# Altium schematic sheet RS485_M_V1.SchDoc
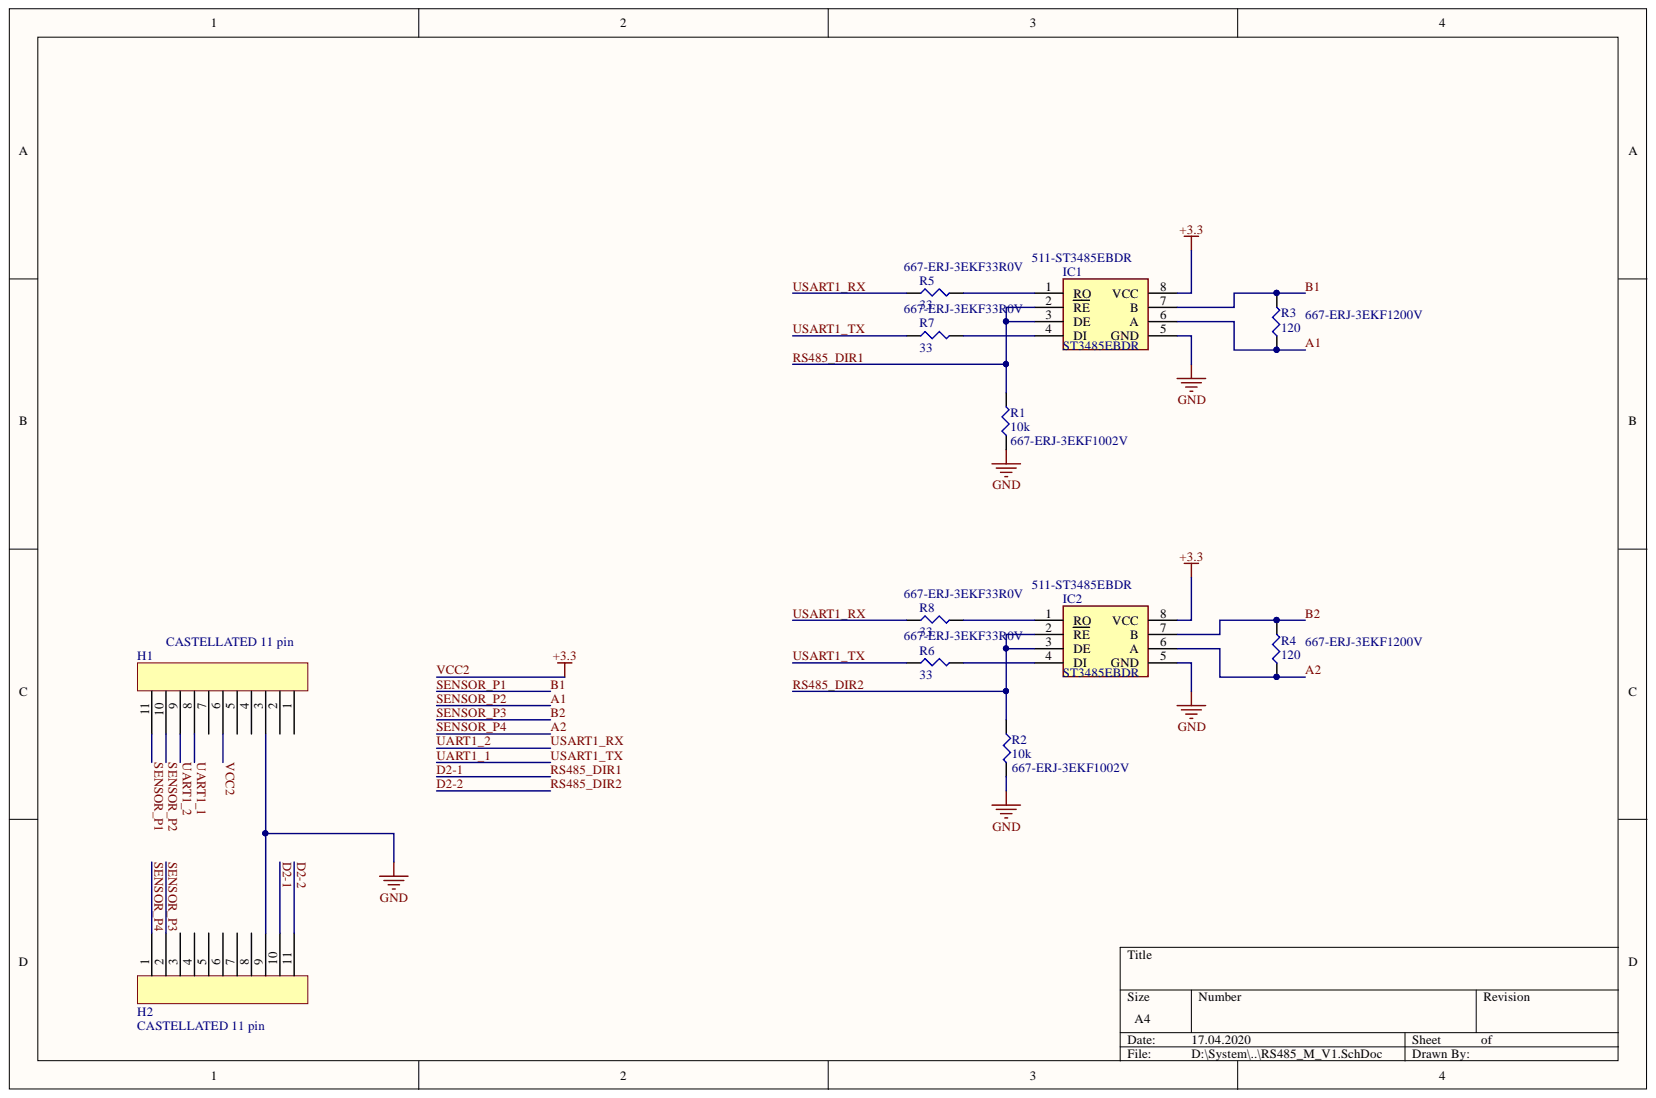
[diagram p0: RS485_M_V1.SchDoc]

## Parts
H1  CASTELLATED 11 pin  [CASTELLATED 11 pin]
H2  CASTELLATED 11 pin  [CASTELLATED 11 pin]
IC1  ST3485EBDR  Mouser2 Part Number: 511-ST3485EBDR  [SOIC127P600X175-8N]
IC2  ST3485EBDR  Mouser2 Part Number: 511-ST3485EBDR  [SOIC127P600X175-8N]
R1  10k  Supplier Part Number 1: 667-ERJ-3EKF1002V  [SMD-0603-RES]
R2  10k  Supplier Part Number 1: 667-ERJ-3EKF1002V  [SMD-0603-RES]
R3  120  Supplier Part Number 1: 667-ERJ-3EKF1200V  [SMD-0603-RES]
R4  120  Supplier Part Number 1: 667-ERJ-3EKF1200V  [SMD-0603-RES]
R5  33  Supplier Part Number 1: 667-ERJ-3EKF33R0V  [SMD-0603-RES]
R6  33  Supplier Part Number 1: 667-ERJ-3EKF33R0V  [SMD-0603-RES]
R7  33  Supplier Part Number 1: 667-ERJ-3EKF33R0V  [SMD-0603-RES]
R8  33  Supplier Part Number 1: 667-ERJ-3EKF33R0V  [SMD-0603-RES]

## Nets
GND: H1.3 H2.9 IC1.5(GND) IC2.5(GND) R1.1 R2.2
A1: H1.10 IC1.6(A) R3.1
A2: H2.1 IC2.6(A) R4.1
B1: H1.11 IC1.7(B) R3.2
B2: H2.2 IC2.7(B) R4.2
D2-1: H2.10 IC1.2(RE) IC1.3(DE) R1.2
D2-2: H2.11 IC2.2(RE) IC2.3(DE) R2.1
UART1_1: H1.8 R6.1 R7.1
UART1_2: H1.9 R5.1 R8.1
VCC2: H1.6 IC1.8(VCC) IC2.8(VCC)
NetIC1_1: IC1.1(RO) R5.2
NetIC1_4: IC1.4(DI) R7.2
NetIC2_1: IC2.1(RO) R8.2
NetIC2_4: IC2.4(DI) R6.2

Not connected: H1 1 2 4 5 7; H2 3 4 5 6 7 8

## Library data of the parts
IC1 IC2 = ST3485EBDR: Integrated Circuit; Manufacturer_Name: STMicroelectronics; Component Kind: Standard; Datasheet Link: https://componentsearchengine.com/Datasheets/1/ST3485EBDR.pdf; Height: 1.75mm; Manufacturer_Part_Number: ST3485EBDR; Mouser2 Price/Stock: https://www.mouser.com/Search/Refine.aspx?Keyword=511-ST3485EBDR; Supplier 1: Mouser; Supplier Part Number 1: 511-ST3485EBDR
R1 R2 = ERJ-3EKF1002V: RES SMD 10K OHM 1% 1/10W 0603; Manufacturer: Panasonic Electronic Components; @ qty: 1500; Factory Stock: 0; Minimum Quantity: 1; Power (Watts): 0.1W, 1/10W; Temperature Coefficient: ±100ppm/°C; Unit Price (USD): 0.00379; Component Kind: Standard; Composition: Thick Film; Datasheets: http://industrial.panasonic.com/www-cgi/jvcr13pz.cgi?E+PZ+3+AOA0002+ERJ3EKF1002V+7+WW; Digi-Key Part Number: P10.0KHCT-ND; Failure Rate: -; Features: Automotive AEC-Q200; Height - Seated (Max): 0.022" (0.55mm); Image: //media.digikey.com/Photos/Panasonic%20Photos/MFG_ERJ-P6W%20Series.jpg; Library Name: Panasonic_ERJ_0603.IntLib; Number of Terminations: 2; Operating Temperature: -55°C ~ 155°C; Package / Case: 0603 (1608 Metric); Packaging: Cut Tape (CT); Part Number: ERJ-3EKF1002V; Part Status: Active; Quantity Available: 6703141; Series: ERJ; Size / Dimension: 0.063" L x 0.031" W (1.60mm x 0.80mm); Supplier 1: Mouser; Supplier Device Package: 0603; Tolerance: ±1%; Value: 10k
R3 R4 = ERJ-3EKF1200V: RES SMD 120 OHM 1% 1/10W 0603; Manufacturer: Panasonic Electronic Components; @ qty: 1500; Factory Stock: 0; Minimum Quantity: 1; Power (Watts): 0.1W, 1/10W; Temperature Coefficient: ±100ppm/°C; Unit Price (USD): 0.00379; Component Kind: Standard; Composition: Thick Film; Datasheets: http://industrial.panasonic.com/www-cgi/jvcr13pz.cgi?E+PZ+3+AOA0002+ERJ3EKF1200V+7+WW; Digi-Key Part Number: P120HCT-ND; Failure Rate: -; Features: Automotive AEC-Q200; Height - Seated (Max): 0.022" (0.55mm); Image: //media.digikey.com/Photos/Panasonic%20Photos/MFG_ERJ-P6W%20Series.jpg; Library Name: Panasonic_ERJ_0603.IntLib; Number of Terminations: 2; Operating Temperature: -55°C ~ 155°C; Package / Case: 0603 (1608 Metric); Packaging: Cut Tape (CT); Part Number: ERJ-3EKF1200V; Part Status: Active; Quantity Available: 84623; Series: ERJ; Size / Dimension: 0.063" L x 0.031" W (1.60mm x 0.80mm); Supplier 1: Mouser; Supplier Device Package: 0603; Tolerance: ±1%; Value: 120
R5-R8 = ERJ-3EKF33R0V: RES SMD 33 OHM 1% 1/10W 0603; Manufacturer: Panasonic Electronic Components; @ qty: 1500; Factory Stock: 0; Minimum Quantity: 1; Power (Watts): 0.1W, 1/10W; Temperature Coefficient: ±100ppm/°C; Unit Price (USD): 0.00379; Component Kind: Standard; Composition: Thick Film; Datasheets: http://industrial.panasonic.com/www-cgi/jvcr13pz.cgi?E+PZ+3+AOA0002+ERJ3EKF33R0V+7+WW; Digi-Key Part Number: P33.0HCT-ND; Failure Rate: -; Features: Automotive AEC-Q200; Height - Seated (Max): 0.022" (0.55mm); Image: //media.digikey.com/Photos/Panasonic%20Photos/MFG_ERJ-P6W%20Series.jpg; Library Name: Panasonic_ERJ_0603.IntLib; Number of Terminations: 2; Operating Temperature: -55°C ~ 155°C; Package / Case: 0603 (1608 Metric); Packaging: Cut Tape (CT); Part Number: ERJ-3EKF33R0V; Part Status: Active; Quantity Available: 1306721; Series: ERJ; Size / Dimension: 0.063" L x 0.031" W (1.60mm x 0.80mm); Supplier 1: Mouser; Supplier Device Package: 0603; Tolerance: ±1%; Value: 33
H1 H2 = CASTELLATED 11 pin: Component Kind: Standard; Library Name: USIB.SchLib
